# Altium schematic sheet STM32.SchDoc(STM32)
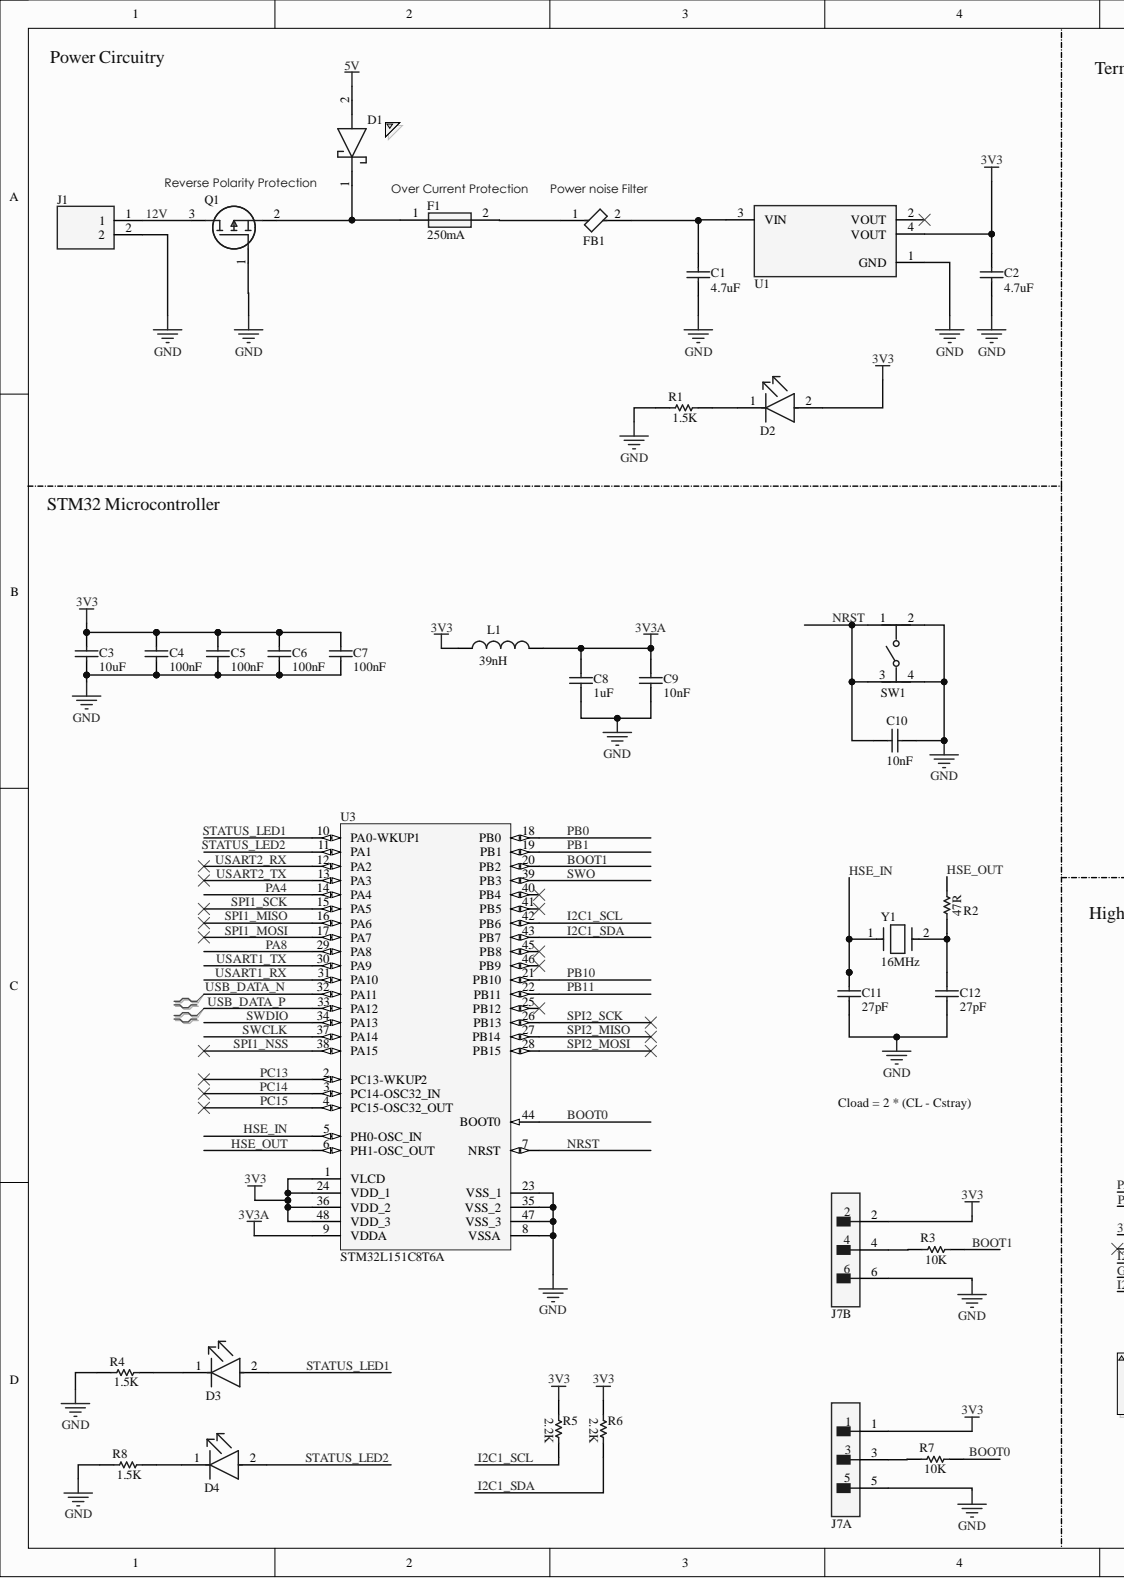
[diagram p0: STM32.SchDoc, left]
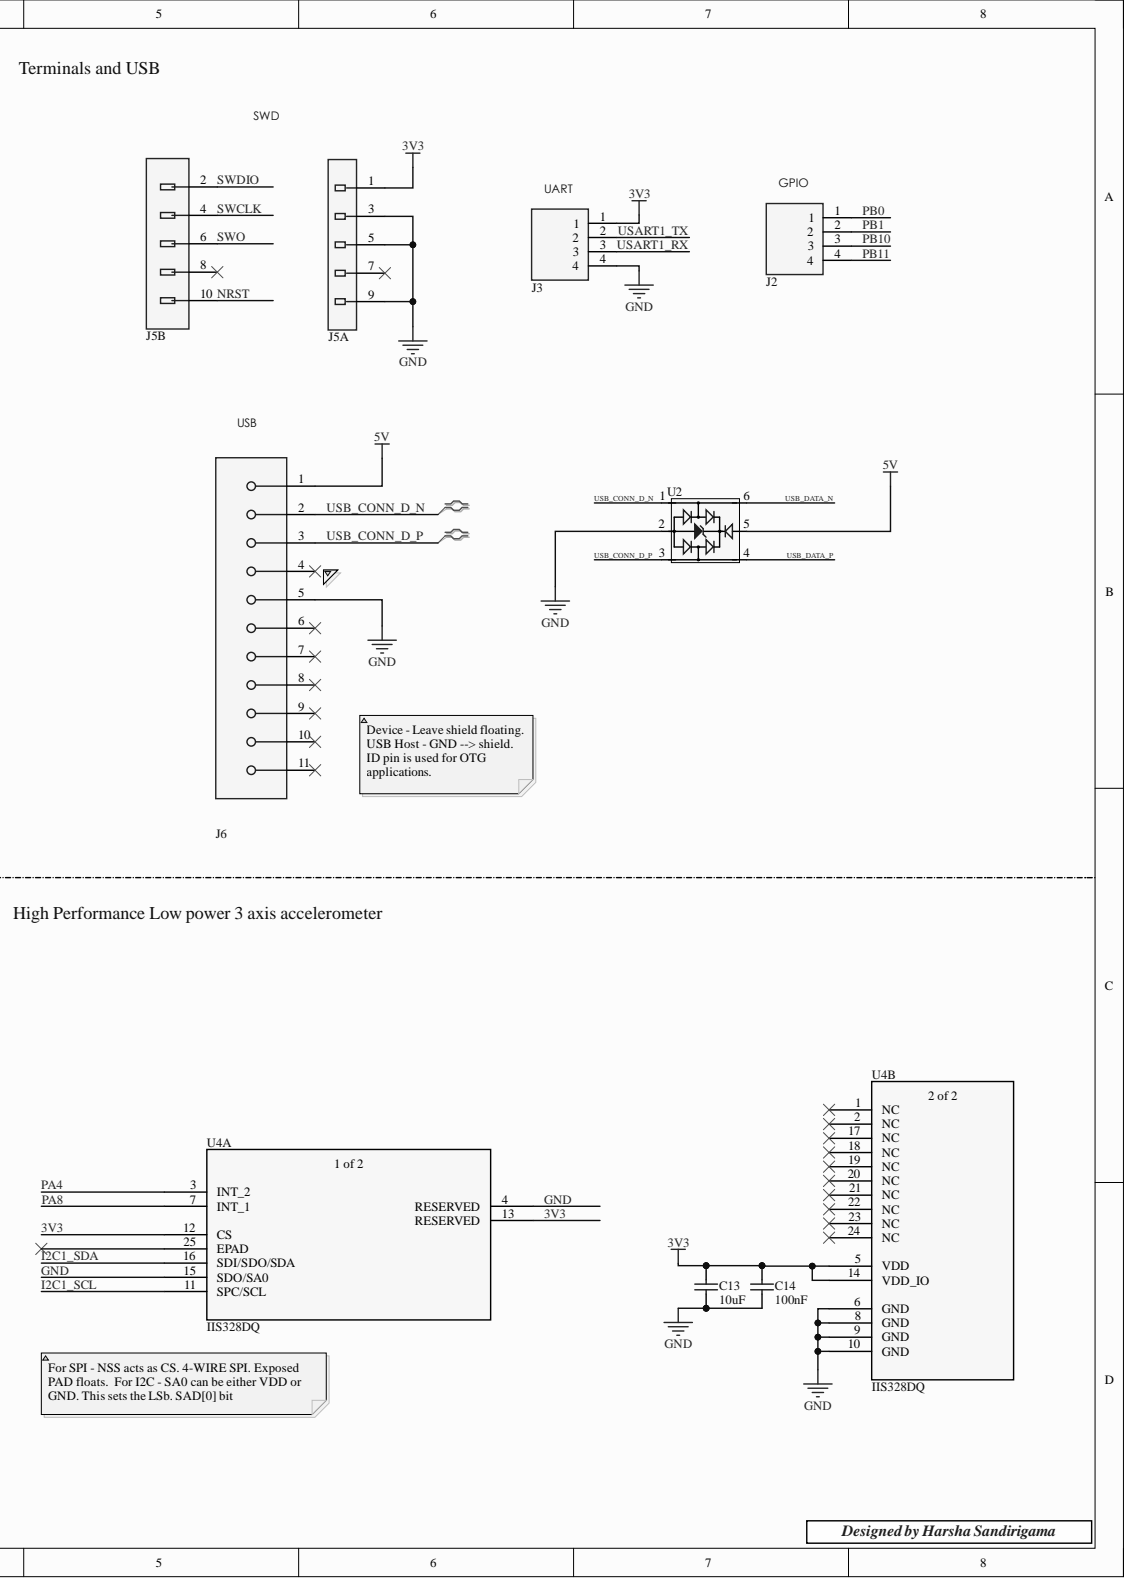
[diagram p1: STM32.SchDoc, right]

## Parts
C1  4.7uF  [0603C - HD]
C2  4.7uF  [0603C - HD]
C3  10uF  [0603C - HD]
C4  100nF  [0603C - HD]
C5  100nF  [0603C - HD]
C6  100nF  [0603C - HD]
C7  100nF  [0603C - HD]
C8  1uF  [0603C - HD]
C9  10nF  [0603C - HD]
C10  10nF  [0603C - HD]
C11  27pF  [0603C - HD]
C12  27pF  [0603C - HD]
C13  10uF  [0603C - HD]
C14  100nF  [0603C - HD]
D1  PMEG4005CEJX  [SODFL2512X80N]  (value not drawn)
D2  LTST-C191KFKT  [Lite-On_0_MFG]  (value not drawn)
D3  LTST-C191KFKT  [Lite-On_0_MFG]  (value not drawn)
D4  LTST-C191KFKT  [Lite-On_0_MFG]  (value not drawn)
F1  250mA  [FUSC3115X66N]
FB1  MPZ1608Y101BTA00  [TDK_MPZ1608-0_8_IPC_A]  (value not drawn)
J1  12V  [PJ037AH]
J2  TS-104-T-AA  [HDRV4W46P0X254_1X4_1016X254X808P]  (value not drawn)
J3  TS-104-T-AA  [HDRV4W46P0X254_1X4_1016X254X808P]  (value not drawn)
J5  GRPB052VWQS-RC  [Sullins_GRPB052VWQS-RC_MFG]  (value not drawn)  (parts A,B)
J6  Connector Type: USB  [Global_Connector_Technology_USB3075-30-A_0]  (hidden comment: USB3075-30-A)
J7  67996-406HLF  [Amphenol_ICC_-_FCI_0_MFG]  (value not drawn)  (parts A,B)
L1  39nH  [0603L - HD]
Q1  MOSFET P-CH  [SOT96P240X110-3N]  (value not drawn)
R1  RMCF0603FT1K50  [0603R - HD]  (value not drawn)
R2  47R  [0603R - HD]
R3  RNCF0603BTE10K0  [0603R - HD]  (value not drawn)
R4  RMCF0603FT1K50  [0603R - HD]  (value not drawn)
R5  RMCF0603FT2K20  [0603R - HD]  (value not drawn)
R6  RMCF0603FT2K20  [0603R - HD]  (value not drawn)
R7  RNCF0603BTE10K0  [0603R - HD]  (value not drawn)
R8  RMCF0603FT1K50  [0603R - HD]  (value not drawn)
SW1  PTS645SM43SMTR92LFS  [C&K_0_MFG - duplicate]  (value not drawn)
U1  ZLDO1117G33TA  [Diodes_SOT223_IPC_A]  (value not drawn)
U2  82400102  [SOT23_6L]  (value not drawn)
U3  STM32L151C8T6A  [QFP50P900X900X160-48N]
U4  IIS328DQ  [QFN-24_4X4MM_STM]  (parts A,B)
Y1  16MHz  [ECS_Inc._ECS-160-20-3X-TR_0]

## Nets
3V3A: C8.2 C9.2 L1.2 U3.9(VDDA)
5V: D1.2 J6.1 U2.5
3V3: C2.2 C3.2 C4.2 C5.2 C6.2 C7.2 C13.2 C14.2 D2.2 J3.1 J5.1 J7.1 J7.2 L1.1 R5.1 R6.1 U1.4(VOUT) U3.1(VLCD) U3.24(VDD_1) U3.36(VDD_2) U3.48(VDD_3) U4.5(VDD) U4.12(CS) U4.13(RESERVED) U4.14(VDD_IO)
12V: J1.1 Q1.3
BOOT0: R7.2 U3.44(BOOT0)
BOOT1: R3.2 U3.20(PB2)
GND: C1.1 C2.1 C3.1 C4.1 C5.1 C6.1 C7.1 C8.1 C9.1 C10.2 C11.1 C12.1 C13.1 C14.1 J1.2 J3.4 J5.3 J5.5 J5.9 J6.5 J7.5 J7.6 Q1.1 R1.1 R4.1 R8.1 SW1.2 SW1.4 U1.1(GND) U2.2 U3.8(VSSA) U3.23(VSS_1) U3.35(VSS_2) U3.47(VSS_3) U4.4(RESERVED) U4.6(GND) U4.8(GND) U4.9(GND) U4.10(GND) U4.15(SDO/SA0)
HSE_IN: C11.2 U3.5(PH0-OSC_IN) Y1.1
HSE_OUT: R2.2 U3.6(PH1-OSC_OUT)
I2C1_SCL: R5.2 U3.42(PB6) U4.11(SPC/SCL)
I2C1_SDA: R6.2 U3.43(PB7) U4.16(SDI/SDO/SDA)
NRST: C10.1 J5.10 SW1.1 SW1.3 U3.7(NRST)
PA4: U3.14(PA4) U4.3(INT_2)
PA8: U3.29(PA8) U4.7(INT_1)
PB0: J2.1 U3.18(PB0)
PB1: J2.2 U3.19(PB1)
PB10: J2.3 U3.21(PB10)
PB11: J2.4 U3.22(PB11)
PC13: U3.2(PC13-WKUP2)
PC14: U3.3(PC14-OSC32_IN)
PC15: U3.4(PC15-OSC32_OUT)
SPI1_MISO: U3.16(PA6)
SPI1_MOSI: U3.17(PA7)
SPI1_NSS: U3.38(PA15)
SPI1_SCK: U3.15(PA5)
SPI2_MISO: U3.27(PB14)
SPI2_MOSI: U3.28(PB15)
SPI2_SCK: U3.26(PB13)
STATUS_LED1: D3.2 U3.10(PA0-WKUP1)
STATUS_LED2: D4.2 U3.11(PA1)
SWCLK: J5.4 U3.37(PA14)
SWDIO: J5.2 U3.34(PA13)
SWO: J5.6 U3.39(PB3)
USART1_RX: J3.3 U3.31(PA10)
USART1_TX: J3.2 U3.30(PA9)
USART2_RX: U3.12(PA2)
USART2_TX: U3.13(PA3)
USB_CONN_D_N: J6.2 U2.1
USB_CONN_D_P: J6.3 U2.3
USB_DATA_N: U2.6 U3.32(PA11)
USB_DATA_P: U2.4 U3.33(PA12)
NetC1_2: C1.2 FB1.2 U1.3(VIN)
NetC12_2: C12.2 R2.1 Y1.2
NetD1_1: D1.1 F1.1 Q1.2
NetD2_1: D2.1 R1.2
NetD3_1: D3.1 R4.2
NetD4_1: D4.1 R8.2
NetF1_2: F1.2 FB1.1
NetJ7_3: J7.3 R7.1
NetJ7_4: J7.4 R3.1

Not connected: J5 7 8; J6 4 6 7 8 9 10 11; U1 2(VOUT); U3 25(PB12) 40(PB4) 41(PB5) 45(PB8) 46(PB9); U4 1(NC) 2(NC) 17(NC) 18(NC) 19(NC) 20(NC) 21(NC) 22(NC) 23(NC) 24(NC) 25(EPAD)

## Library data of the parts
C1 C2 = C1608X7S1A475K080AC: Cap Ceramic 4.7uF 10V X7S 10% SMD 0603 125°C Punched Paper T/R; Manufacturer: TDK; Core Architecture: ARM; Frequency: 48MHz; Interface: I2C,SPI,USB,IrDA,UART,USART,EBI/EMI; Memory Size: 64KB; Memory Type: FLASH; Number of I/Os: 53; Oscillator Type: Internal; Peripherals: Brown-out Detect/Reset,POR,PWM,WDT,DMA,LCD; Capacitance: 4.7uF; Case Code (Imperial): 0603; Case Code (Metric): 1608; Case/Package: LFBGA; Category: Ceramic Capacitors; Component Kind: Standard; ComponentLink1Description: https://datasheet.ciiva.com/14911/tdkmlcccaprange-14911942.pdf?src-supplier=Digi-Key; ComponentLink1URL: https://datasheet.ciiva.com/14911/tdkmlcccaprange-14911942.pdf?src-supplier=Digi-Key; ComponentLink2Description: https://datasheet.ciiva.com/14911/tdkmlcccaprange-14911943.pdf?src-supplier=Digi-Key; ComponentLink2URL: https://datasheet.ciiva.com/14911/tdkmlcccaprange-14911943.pdf?src-supplier=Digi-Key; ComponentLink3Description: https://datasheet.ciiva.com/14911/tdkmlcccaprange-14911944.pdf?src-supplier=Digi-Key; ComponentLink3URL: https://datasheet.ciiva.com/14911/tdkmlcccaprange-14911944.pdf?src-supplier=Digi-Key; Depth: 0.8mm; Dielectric: X7S; Dielectric Material: Ceramic; Lead Free: Lead Free; Length: 1.6mm; Manufacturer Part Number: C1608X7S1A475K080AC; Max Operating Temperature: 125°C; Min Operating Temperature: -40°C; Number of Pins: 64; Package Quantity: 1000; Packaging: Tape and Reel; Pricing 1: 1=0.30000, 10=0.20700, 50=0.12420, 100=0.10490, 500=0.07176, 1000=0.06348 (USD); REACH SVHC: No SVHC; RoHS: Yes; RoHS Compliant: Yes; Series: Leopard Gecko; Stock 1: 598789; Supplier 1: Digi-Key; Supplier Part Number 1: 445-14258-1-ND; Thickness: 800um; Tolerance: 10%; Voltage Rating: 10V; Voltage Rating (DC): 10V; Library Name: stm32devsch.SchLib
C3 C13 = 06036D106MAT2A: AVX - 06036D106MAT2A - SMD Multilayer Ceramic Capacitor, 10 µF, 6.3 V, 0603 [1608 Metric], ± 20%, X5R, AVX 0603 MLCC; Manufacturer: Kyocera AVX; Voltage: 6.3V; Capacitance: 10uF; Case Code (Imperial): 0603; Case Code (Metric): 1608; Case/Package: 0603; Category: Ceramic Capacitors; Component Kind: Standard; ComponentLink1Description: https://datasheet.ciiva.com/153/cx5r-153301.pdf?src-supplier=Digi-Key; ComponentLink1URL: https://datasheet.ciiva.com/153/cx5r-153301.pdf?src-supplier=Digi-Key; ComponentLink2Description: https://datasheet.ciiva.com/153/cx5r-153302.pdf?src-supplier=Digi-Key; ComponentLink2URL: https://datasheet.ciiva.com/153/cx5r-153302.pdf?src-supplier=Digi-Key; ComponentLink3Description: https://datasheet.ciiva.com/153/cx5r-153303.pdf?src-supplier=Digi-Key; ComponentLink3URL: https://datasheet.ciiva.com/153/cx5r-153303.pdf?src-supplier=Digi-Key; ComponentLink4Description: https://datasheet.ciiva.com/5422/cx5r-33896-5422935.pdf?src-supplier=Mouser; ComponentLink4URL: https://datasheet.ciiva.com/5422/cx5r-33896-5422935.pdf?src-supplier=Mouser; ComponentLink5Description: https://datasheet.ciiva.com/2987/cx5r-2987866.pdf?src-supplier=Avnet; ComponentLink5URL: https://datasheet.ciiva.com/2987/cx5r-2987866.pdf?src-supplier=Avnet; ComponentLink6Description: https://datasheet.ciiva.com/2987/cx5r-2987867.pdf?src-supplier=Avnet; ComponentLink6URL: https://datasheet.ciiva.com/2987/cx5r-2987867.pdf?src-supplier=Avnet; ComponentLink7Description: https://datasheet.ciiva.com/14591/70001028-14591754.pdf?src-supplier=Allied+Electronics; ComponentLink7URL: https://datasheet.ciiva.com/14591/70001028-14591754.pdf?src-supplier=Allied+Electronics; ComponentLink8Description: https://datasheet.ciiva.com/16201/cx5r-16201627.pdf?src-supplier=Future+Electronics; ComponentLink8URL: https://datasheet.ciiva.com/16201/cx5r-16201627.pdf?src-supplier=Future+Electronics; ComponentLink9Description: https://datasheet.ciiva.com/1458/1796776-1458117.pdf?src-supplier=Element14; ComponentLink9URL: https://datasheet.ciiva.com/1458/1796776-1458117.pdf?src-supplier=Element14; ComponentLink10Description: https://datasheet.ciiva.com/16538/cx5r-16538025.pdf?src-supplier=Avnet+Asia; ComponentLink10URL: https://datasheet.ciiva.com/16538/cx5r-16538025.pdf?src-supplier=Avnet+Asia; Construction: Flat; Contact Plating: Tin,Matte; Depth: 0.81mm; Dielectric: X5R; Dielectric Material: Ceramic; Height: 0.9mm; Lead Free: Lead Free; Length: 1.6mm; Manufacturer Part Number: 06036D106MAT2A; Max Operating Temperature: 85°C; Min Operating Temperature: -55°C; Mount: Surface Mount,PCB; Number of Pins: 2; Package Quantity: 1; Packaging: Tape and Reel; Pricing 1: 1=0.22000, 10=0.14900, 50=0.08940, 100=0.07550, 500=0.05164, 1000=0.04568 (USD); Pricing 2: 1=0.22000, 10=0.14900, 50=0.08940, 100=0.07550, 500=0.05164, 1000=0.04568 (USD); Radiation Hardening: No; REACH SVHC: No SVHC; RoHS: Yes; RoHS Compliant: Yes; Series: -; Stock 1: 923568; Stock 2: 923568; Supplier 1: Digi-Key; Supplier 2: Digi-Key; Supplier Part Number 1: 478-5318-1-ND; Supplier Part Number 2: 478-5318-6-ND; Termination: SMD/SMT; Thickness: 0.035inch; Tolerance: 20%; Voltage Rating: 6.3V; Voltage Rating (DC): 6.3V; Width: 0.032inch; Library Name: stm32devsch.SchLib
C4-C7 C14 = CL10B104KB8VPJC: 0.1 µF ±10% 50V Ceramic Capacitor X7R 0603 (1608 Metric); Manufacturer: Samsung; Category: Ceramic Capacitors; Component Kind: Standard; Manufacturer Part Number: CL10B104KB8VPJC; Pricing 1: 1=0.15000, 10=0.11000, 50=0.06240, 100=0.05180, 500=0.03630, 1000=0.03005 (USD); Pricing 2: 1=0.16000, 10=0.07500, 50=0.07500, 100=0.05500, 1000=0.03400, 10000=0.02400 (USD); RoHS: Yes; Stock 2: 5857; Supplier 1: Digi-Key; Supplier 2: Mouser; Supplier Part Number 1: 1276-CL10B104KB8VPJCCT-ND; Supplier Part Number 2: 187-CL10B104KB8VPJC; Library Name: stm32devsch.SchLib
C8 = 0603BB105K160YT: CAP CER 1UF 16V X7R 0603; Manufacturer: Knowles Novacap; Tolerance: 10%; Capacitance: 1uF; Case/Package: 0603; Category: Ceramic Capacitors; Component Kind: Standard; ComponentLink1Description: http://www.knowlescapacitors.com/getmedia/b8129730-c7fe-4622-a4e1-683ad9deee84/Novacap_catalog_9345-14.aspx?src-supplier=Digi-Key; ComponentLink1URL: http://www.knowlescapacitors.com/getmedia/b8129730-c7fe-4622-a4e1-683ad9deee84/Novacap_catalog_9345-14.aspx?src-supplier=Digi-Key; Manufacturer Part Number: 0603BB105K160YT; Max Operating Temperature: 125°C; Min Operating Temperature: -55°C; Mount: Surface Mount; Packaging: Cut Tape; RoHS: No; Supplier 1: Digi-Key; Supplier Part Number 1: 1763-1175-1-ND; Voltage Rating: 16V; Library Name: stm32devsch.SchLib
C9 C10 = CL10B103KB8WPJC: Capacitor Ceramic 0.01uF 10% 50V 2-Pin Surface Mount T/R; Manufacturer: Samsung; Category: Ceramic Capacitors; Component Kind: Standard; Manufacturer Part Number: CL10B103KB8WPJC; Pricing 1: 1=0.11000, 10=0.08100, 50=0.04620, 100=0.03830, 500=0.02684, 1000=0.02222 (USD); RoHS: Yes; RoHS Compliant: Yes; Stock 1: 74489; Supplier 1: Digi-Key; Supplier Part Number 1: 1276-CL10B103KB8WPJCCT-ND; Library Name: stm32devsch.SchLib
C11 C12 = 06035A270JAT2A: CAP MLCC, 27PF, 50V, C0G/NP0, 5%, 0603; Manufacturer: Kyocera AVX; Dielectric: C0G; Temperature Coefficient: 0ppm/°C; Capacitance: 27pF; Case Code (Imperial): 0603; Case Code (Metric): 1608; Case/Package: 0603; Category: Ceramic Capacitors; Component Kind: Standard; ComponentLink1Description: https://datasheet.ciiva.com/22738/759117-22738072.pdf?src-supplier=Element14; ComponentLink1URL: https://datasheet.ciiva.com/22738/759117-22738072.pdf?src-supplier=Element14; ComponentLink2Description: https://datasheet.ciiva.com/7855/759117-7855066.pdf?src-supplier=Element14; ComponentLink2URL: https://datasheet.ciiva.com/7855/759117-7855066.pdf?src-supplier=Element14; ComponentLink3Description: https://datasheet.ciiva.com/150/ccog-150312.pdf?src-supplier=Digi-Key; ComponentLink3URL: https://datasheet.ciiva.com/150/ccog-150312.pdf?src-supplier=Digi-Key; ComponentLink4Description: https://datasheet.ciiva.com/150/ccog-150311.pdf?src-supplier=Digi-Key; ComponentLink4URL: https://datasheet.ciiva.com/150/ccog-150311.pdf?src-supplier=Digi-Key; ComponentLink5Description: https://datasheet.ciiva.com/150/ccog-150313.pdf?src-supplier=Digi-Key; ComponentLink5URL: https://datasheet.ciiva.com/150/ccog-150313.pdf?src-supplier=Digi-Key; Contact Plating: Tin,Matte; Depth: 0.81mm; Height: 0.63mm; Lead Free: Lead Free; Length: 1.6mm; Manufacturer Part Number: 06035A270JAT2A; Max Operating Temperature: 125°C; Min Operating Temperature: -55°C; Mount: Surface Mount; Number of Pins: 2; Package Quantity: 1; Packaging: Tape and Reel; Pricing 1: 1=0.10000, 10=0.07000, 50=0.03800, 100=0.03100, 500=0.02214, 1000=0.01739 (USD); Radiation Hardening: No; REACH SVHC: No SVHC; RoHS: Yes; Stock 1: 36247; Supplier 1: Digi-Key; Supplier Part Number 1: 478-1168-1-ND; Termination: SMD/SMT; Thickness: 0.035inch; Tolerance: 5%; Voltage: 50V; Voltage Rating: 50V; Voltage Rating (DC): 50V; Width: 0.81mm; Library Name: stm32devsch.SchLib
D1 = PMEG4005CEJX: Rectifier Diode Schottky 40V 0.5A 1.8ns Automotive 2-Pin SOD-323F T/R; Manufacturer: Nexperia; Arrow Part Number: PMEG4005CEJX; Arrow Price/Stock: https://www.arrow.com/en/products/pmeg4005cejx/nexperia?region=nac; Category: Schottky Diodes; Component Kind: Standard; Datasheet Link: https://www.arrow.com/en/products/pmeg4005cejx/nexperia; Height: 0.8mm; Manufacturer Part Number: PMEG4005CEJX; Manufacturer_Name: Nexperia; Manufacturer_Part_Number: PMEG4005CEJX; Mouser Part Number: 771-PMEG4005CEJX; Mouser Price/Stock: https://www.mouser.co.uk/ProductDetail/Nexperia/PMEG4005CEJX?qs=1fQ7IOp4Wri3RvgD47E2KA%3D%3D; Pricing 1: 1=0.42000, 10=0.30900, 100=0.17490, 500=0.11584, 1000=0.08880 (USD); RoHS: Yes; RoHS Compliant: Yes; Stock 1: 29989; Supplier 1: Digi-Key; Supplier Part Number 1: 1727-8169-1-ND; Library Name: stm32devsch.SchLib
D2-D4 = LTST-C191KFKT: Orange 0603 130° Water Clear 90 mcd 2 V SMT Rectangular Flat Top LED; Manufacturer: Vishay Lite-On; Dominant Wavelength: 605nm; Forward Current: 30mA; Forward Voltage: 2V; Lens Color: Colorless; Lens Style: Diffused,Colorless,Rectangular; Luminous Intensity: 90mcd; Number of Elements: 1; Power Dissipation: 75mW; Reverse Voltage: 5V; Test Current: 20mA; Wavelength: 611nm; Case Code (Imperial): 0603; Case Code (Metric): 1608; Case/Package: 0603; Category: LEDs; Color: Orange; Component Kind: Standard; ComponentLink1Description: https://datasheet.ciiva.com/2109/ltst-c191kfkt-2109968.pdf?src-supplier=Digi-Key; ComponentLink1URL: https://datasheet.ciiva.com/2109/ltst-c191kfkt-2109968.pdf?src-supplier=Digi-Key; ComponentLink2Description: https://datasheet.ciiva.com/12400/100-ltst-c191kfkt-39904-12400811.pdf?src-supplier=Mouser; ComponentLink2URL: https://datasheet.ciiva.com/12400/100-ltst-c191kfkt-39904-12400811.pdf?src-supplier=Mouser; ComponentLink3Description: https://datasheet.ciiva.com/11775/0900766b80dc02b0-11775613.pdf?src-supplier=RSComponents; ComponentLink3URL: https://datasheet.ciiva.com/11775/0900766b80dc02b0-11775613.pdf?src-supplier=RSComponents; ComponentLink4Description: https://datasheet.ciiva.com/15201/getdatasheetpartid-631075-15201391.pdf?src-supplier=Verical; ComponentLink4URL: https://datasheet.ciiva.com/15201/getdatasheetpartid-631075-15201391.pdf?src-supplier=Verical; ComponentLink5Description: https://datasheet.ciiva.com/2109/s-110-ltst-c191kfkt-2109969.pdf?src-supplier=Digi-Key; ComponentLink5URL: https://datasheet.ciiva.com/2109/s-110-ltst-c191kfkt-2109969.pdf?src-supplier=Digi-Key; Depth: 0.8mm; Height: 0.55mm; Illumination Color: Orange; Lead Free: Lead Free; Length: 1.6mm; Lens Transparency: Clear; Manufacturer Part Number: LTST-C191KFKT; Max Operating Temperature: 85°C; Min Operating Temperature: -55°C; Mount: Surface Mount; Number of LEDs: 1; Number of Pins: 2; Package Quantity: 1; Packaging: Tape and Reel; Power Rating: 75mW; Pricing 1: 1=0.24000, 10=0.13200, 100=0.06110, 500=0.05640, 1000=0.04418, 2000=0.04324 (USD); Radiation Hardening: No; RoHS: Yes; RoHS Compliant: Yes; Stock 1: 298039; Supplier 1: Digi-Key; Supplier Part Number 1: 160-1445-1-ND; Viewing Angle: 130°; Width: 0.8mm; Library Name: stm32devsch.SchLib
F1 = C1Q250: Fuse Chip Very Fast Acting 0.25A 125V SMD Solder Pad 1206 Ceramic T/R CE/CSA/cULus; Manufacturer: Bel; Manufacturer_Name: Stewart Connector; Approvals: CSA,cULus,CE; DC Cold Resistance: 880mR; Fuse Type: Board Mount (Cartridge Style Excluded); Max Voltage Rating (DC): 63V; Power Dissipation: 100mW; Case Code (Imperial): 1206; Case Code (Metric): 3216; Case/Package: 1206; Category: Fuses; Component Kind: Standard; ComponentLink1Description: https://datasheet.ciiva.com/300/c1q-300584.pdf?src-supplier=Digi-Key; ComponentLink1URL: https://datasheet.ciiva.com/300/c1q-300584.pdf?src-supplier=Digi-Key; ComponentLink2Description: https://datasheet.ciiva.com/300/c1q-300585.pdf?src-supplier=Digi-Key; ComponentLink2URL: https://datasheet.ciiva.com/300/c1q-300585.pdf?src-supplier=Digi-Key; ComponentLink3Description: https://datasheet.ciiva.com/300/c1q-300586.pdf?src-supplier=Digi-Key; ComponentLink3URL: https://datasheet.ciiva.com/300/c1q-300586.pdf?src-supplier=Digi-Key; ComponentLink4Description: https://datasheet.ciiva.com/8264/c1q-8264010.pdf?src-supplier=Avnet; ComponentLink4URL: https://datasheet.ciiva.com/8264/c1q-8264010.pdf?src-supplier=Avnet; Contact Plating: Tin; Current Rating: 250mA; Datasheet Link: https://datasheet.datasheetarchive.com/originals/distributors/Datasheets-6/DSA-118457.pdf; Depth: 1.52mm; Height: 0.66mm; Housing Material: Ceramic; Lead Free: Lead Free; Length: 3.07mm; Manufacturer Part Number: C1Q250; Manufacturer_Part_Number: C1Q250; Max Operating Temperature: 125°C; Min Operating Temperature: -55°C; Mount: Surface Mount; Number of Pins: 2; Packaging: Tape and Reel; Pricing 1: 1=0.32000, 5=0.31200, 10=0.29900, 50=0.26680, 100=0.24840, 250=0.22080, 500=0.18400, 1000=0.17480 (USD); RoHS: Yes; RoHS Compliant: Yes; Series: C1Q; Stock 1: 66554; Supplier 1: Digi-Key; Supplier Part Number 1: 507-1073-1-ND; Voltage Rating: 125V; Voltage Rating (AC): 125V; Voltage Rating (DC): 63V; Width: 0.062inch; Library Name: stm32devsch.SchLib
FB1 = MPZ1608Y101BTA00: Ind Chip Bead Multi-Layer 100Ohm 25% 100MHz Ferrite 2A 0603 Punched Paper T/R; Manufacturer: TDK; DC Resistance (DCR): 40mR; Impedance: 100R; Case Code (Imperial): 0603; Case Code (Metric): 1608; Case/Package: 0603; Category: Ferrite Beads and Chips; Component Kind: Standard; ComponentLink1Description: https://datasheet.ciiva.com/46634/beads-commercial-power-mpz-en-46634621.pdf?src-supplier=Digi-Key; ComponentLink1URL: https://datasheet.ciiva.com/46634/beads-commercial-power-mpz-en-46634621.pdf?src-supplier=Digi-Key; ComponentLink2Description: https://datasheet.ciiva.com/46634/beads-commercial-power-mpz-en-46634677.pdf?src-supplier=Digi-Key; ComponentLink2URL: https://datasheet.ciiva.com/46634/beads-commercial-power-mpz-en-46634677.pdf?src-supplier=Digi-Key; ComponentLink3Description: https://datasheet.ciiva.com/46634/beads-commercial-power-mpz-en-46634684.pdf?src-supplier=Digi-Key; ComponentLink3URL: https://datasheet.ciiva.com/46634/beads-commercial-power-mpz-en-46634684.pdf?src-supplier=Digi-Key; ComponentLink4Description: https://datasheet.ciiva.com/48682/beads-commercial-power-mpz-en-48682501.pdf?src-supplier=Avnet; ComponentLink4URL: https://datasheet.ciiva.com/48682/beads-commercial-power-mpz-en-48682501.pdf?src-supplier=Avnet; Core Material: Ferrite; Current Rating: 2A; Height: 0.037inch; Length: 0.063inch; Manufacturer Part Number: MPZ1608Y101BTA00; Max DC Current: 2A; Mount: Surface Mount; Package Quantity: 4000; Packaging: Tape and Reel; Pricing 1: 1=0.10000, 10=0.07900, 25=0.07160, 50=0.06440, 100=0.04720, 250=0.03432, 500=0.03146, 1000=0.02717 (USD); REACH SVHC: No SVHC; RoHS: Yes; RoHS Compliant: Yes; Series: MPZ; Stock 1: 221776; Supplier 1: Digi-Key; Supplier Part Number 1: 445-2984-1-ND; Tolerance: 25%; Width: 0.031inch; Library Name: stm32devsch.SchLib
J1 = PJ-037AH: CONN PWR JACK 2X5.5MM SOLDER; Manufacturer: CUI; Manufacturer_Name: CUI Inc.; Current Rating: 5A; Gender: Male; Insulation Resistance: 100MR; Number of Conductors: 2; Shielding: Unshielded; Voltage Rating: 24V; Arrow Part Number: PJ-037AH; Arrow Price/Stock: https://www.arrow.com/en/products/pj-037ah/cui-devices?region=nac; Category: Connectors; Color: Black; Component Kind: Standard; ComponentLink1Description: http://www.mouser.com/ds/2/670/pj-037ah-516064.pdf?src-supplier=Mouser; ComponentLink1URL: http://www.mouser.com/ds/2/670/pj-037ah-516064.pdf?src-supplier=Mouser; ComponentLink2Description: https://www.cui.com/product/resource/digikeypdf/pj-037ah.pdf?src-supplier=Digi-Key; ComponentLink2URL: https://www.cui.com/product/resource/digikeypdf/pj-037ah.pdf?src-supplier=Digi-Key; Connector Type: Jack; Contact Gender: Male; Contact Material: Copper; Contact Plating: Nickel; Datasheet Link: https://www.arrow.com/en/products/pj-037ah/cui-devices; Flammability Rating: UL94 V-0; Height: 11.2mm; Housing Color: Black; Housing Material: Thermoplastic; Lead Free: Lead Free; Manufacturer Part Number: PJ-037AH; Manufacturer_Part_Number: PJ-037AH; Max Operating Temperature: 85°C; Min Operating Temperature: -25°C; Mount: Through Hole; Mouser Part Number: 490-PJ-037AH; Mouser Price/Stock: https://www.mouser.co.uk/ProductDetail/CUI-Devices/PJ-037AH?qs=WyjlAZoYn50M7m1AkzfPaQ%3D%3D; Orientation: Horizontal; Package Quantity: 500; Pricing 1: 1=0.71000, 10=0.62200, 25=0.56880, 50=0.53520, 100=0.51290, 250=0.46832, 500=0.44600, 1000=0.36795, 2500=0.33450 (USD); RoHS: Yes; RoHS Compliant: Yes; Series: -; Stock 1: 64914; Supplier 1: Digi-Key; Supplier Part Number 1: CP-037AH-ND; Termination: Solder; Library Name: stm32devsch.SchLib
J2 J3 = TS-104-T-AA: Connector; Manufacturer_Name: SAMTEC; Arrow Part Number: TS-104-T-AA; Arrow Price/Stock: https://www.arrow.com/en/products/ts-104-t-aa/samtec?region=nac; Component Kind: Standard; Datasheet Link: https://componentsearchengine.com/Datasheets/2/TS-104-T-AA.pdf; Height: 8.08mm; Manufacturer_Part_Number: TS-104-T-AA; Mouser Part Number: 200-TS104TAA; Mouser Price/Stock: https://www.mouser.co.uk/ProductDetail/Samtec/TS-104-T-AA?qs=0lQeLiL1qyZ41w70Oe2T%2Fg%3D%3D; Library Name: stm32devsch.SchLib
J5 = GRPB052VWQS-RC: Male, 10 C, SMT, .050" CC; 3.00mm Head/5.10mm Tail, Sf Mt; No GP, Nylon 9T, Brass, 105 C; Manufacturer: Sullins; Connector Type: Male Pin; Number of Positions: 10; Pitch: 0.05inch; Category: Headers and Wire Housings; Component Kind: Standard; ComponentLink1Description: https://datasheet.ciiva.com/726/grpb-20-2vwqs-rc2010958-c-726624.pdf?src-supplier=Digi-Key; ComponentLink1URL: https://datasheet.ciiva.com/726/grpb-20-2vwqs-rc2010958-c-726624.pdf?src-supplier=Digi-Key; Contact Mating Length: 0.118inch; Contact Plating: Gold; Contact Type: Male Pin; Lead Free: Lead Free; Manufacturer Part Number: GRPB052VWQS-RC; Mount: Surface Mount; Number of Rows: 2; Package Quantity: 100; Packaging: Bulk; Pricing 1: 1=1.05000, 10=0.88400, 96=0.79396, 480=0.64963, 960=0.57744, 4992=0.52130 (USD); RoHS: Yes; RoHS Compliant: Yes; Row Spacing: 0.05inch; Stock 1: 18930; Supplier 1: Digi-Key; Supplier Part Number 1: S9012E-05-ND; Termination: Solder; Library Name: stm32devsch.SchLib
J6 = USB3075-30-A: Conn Micro USB Type B RCP 2Power/3Signal POS 0.65mm Solder RA SMD 5 Terminal 1 Port T/R; Manufacturer: Global Connector Technology; Gender: Receptacle; Number of Contacts: 5; USB Standard: USB 2.0; Category: USB Connectors; Component Kind: Standard; ComponentLink1Description: https://datasheet.ciiva.com/5803/usb3075-5803809.pdf?src-supplier=Farnell; ComponentLink1URL: https://datasheet.ciiva.com/5803/usb3075-5803809.pdf?src-supplier=Farnell; ComponentLink2Description: https://datasheet.ciiva.com/46420/usb3075-46420605.pdf?src-supplier=Element14; ComponentLink2URL: https://datasheet.ciiva.com/46420/usb3075-46420605.pdf?src-supplier=Element14; ComponentLink3Description: https://datasheet.ciiva.com/7633/1912469-7633847.pdf?src-supplier=Element14; ComponentLink3URL: https://datasheet.ciiva.com/7633/1912469-7633847.pdf?src-supplier=Element14; ComponentLink4Description: https://datasheet.ciiva.com/16502/usb3075-16502716.pdf?src-supplier=Future+Electronics; ComponentLink4URL: https://datasheet.ciiva.com/16502/usb3075-16502716.pdf?src-supplier=Future+Electronics; Contact Material: Copper Alloy; Contact Plating: Gold; Height: 0.525inch; Lead Free: Lead Free; Length: 0.525inch; Manufacturer Part Number: USB3075-30-A; Mount: Surface Mount; Orientation: Right Angle; Pricing 1: 1=0.87500, 250=0.67300, 500=0.60600, 1000=0.55200, 1500=0.55200, 5000=0.50500 (USD); Pricing 2: 1500=0.33300, 7500=0.32600, 15000=0.32000, 30000=0.31300 (GBP); REACH SVHC: No SVHC; RoHS: Yes; RoHS Compliant: Yes; Series: USB3075; Stock 1: 25500; Stock 2: 25500; Supplier 1: Newark; Supplier 2: Farnell; Supplier Part Number 1: 69AC6678; Supplier Part Number 2: 2443116; Termination: SMD/SMT; Weight: 0.001lb; Width: 0.525inch; Library Name: stm32devsch.SchLib
J7 = 67996-406HLF: CONN HEADER VERT 6POS 2.54MM; Manufacturer: Amphenol ICC / FCI; Connector Type: Male Pin; Contact Post Length: 2.41mm; Current Rating: 3A; Gender: Male; Insulation Resistance: 5GR; Max Voltage Rating (AC): 1.5kV; Number of Contacts: 6; Row Spacing: 0.1inch; Category: Headers and Wire Housings; Color: Black; Component Kind: Standard; ComponentLink1Description: https://datasheet.ciiva.com/46393/1876884-46393070.pdf?src-supplier=Element14; ComponentLink1URL: https://datasheet.ciiva.com/46393/1876884-46393070.pdf?src-supplier=Element14; ComponentLink2Description: https://datasheet.ciiva.com/728/67996-728895.pdf?src-supplier=Digi-Key; ComponentLink2URL: https://datasheet.ciiva.com/728/67996-728895.pdf?src-supplier=Digi-Key; ComponentLink3Description: https://datasheet.ciiva.com/3205/67996-3205613.pdf?src-supplier=Avnet; ComponentLink3URL: https://datasheet.ciiva.com/3205/67996-3205613.pdf?src-supplier=Avnet; ComponentLink4Description: https://datasheet.ciiva.com/16726/67996-16726281.pdf?src-supplier=Avnet+Europe; ComponentLink4URL: https://datasheet.ciiva.com/16726/67996-16726281.pdf?src-supplier=Avnet+Europe; ComponentLink5Description: https://datasheet.ciiva.com/14639/70236872-14639895.pdf?src-supplier=Allied+Electronics; ComponentLink5URL: https://datasheet.ciiva.com/14639/70236872-14639895.pdf?src-supplier=Allied+Electronics; Contact Gender: Male; Contact Material: Bronze; Contact Mating Length: 0.23inch; Contact Plating: Tin; Contact Type: Male Pin; Depth: 4.83mm; Housing Color: Black; Housing Material: Thermoplastic; Length: 7.62mm; Manufacturer Part Number: 67996-406HLF; Max Operating Temperature: 125°C; Min Operating Temperature: -65°C; Mount: Through Hole; Number of Positions: 6; Number of Rows: 2; Orientation: Straight; Package Quantity: 100; Packaging: Bulk; Pitch: 2.54mm; Pricing 1: 1=0.29000, 10=0.27100, 100=0.18940, 500=0.16234, 1000=0.13528, 5000=0.11595, 10000=0.10822 (USD); Radiation Hardening: No; REACH SVHC: No SVHC; RoHS: Yes; RoHS Compliant: Yes; Series: BergStik; Stock 1: 5419; Supplier 1: Digi-Key; Supplier Part Number 1: 609-3218-ND; Termination: Solder; Voltage Rating: 1.5kV; Library Name: stm32devsch.SchLib
L1 = HK160839NJ-T: Ind High Frequency Chip Multi-Layer 39nH 5% 100MHz 12Q-Factor Ceramic 300mA 0603 T/R; Manufacturer: Taiyo Yuden; Core Material: Ferrite,Ceramic; DC Resistance (DCR): 600mR; Q Factor: 12; Self Resonant Frequency: 1.1GHz; Shielding: Unshielded; Body Material: Ceramic; Case Code (Imperial): 0603; Case Code (Metric): 1608; Case/Package: 0603; Category: Fixed Inductors; Component Kind: Standard; ComponentLink1Description: https://datasheet.ciiva.com/8499/hk160839nj-t-ss-8499010.pdf?src-supplier=Avnet; ComponentLink1URL: https://datasheet.ciiva.com/8499/hk160839nj-t-ss-8499010.pdf?src-supplier=Avnet; ComponentLink2Description: https://datasheet.ciiva.com/30193/1171hk160839nj-t-30193223.pdf?src-supplier=Onlinecomponents.com; ComponentLink2URL: https://datasheet.ciiva.com/30193/1171hk160839nj-t-30193223.pdf?src-supplier=Onlinecomponents.com; ComponentLink3Description: https://datasheet.ciiva.com/7153/hk160839nj-t-ss-7153953.pdf?src-supplier=Newark; ComponentLink3URL: https://datasheet.ciiva.com/7153/hk160839nj-t-ss-7153953.pdf?src-supplier=Newark; Current Rating: 300mA; Halogen Free: Halogen Free; Height: 0.8mm; Height - Seated (Max): 0.037inch; Inductance: 39nH; Inductance Tolerance: 5%; Lead Free: Exempt; Length: 0.063inch; Manufacturer Part Number: HK160839NJ-T; Max DC Current: 300mA; Max Operating Temperature: 85°C; Min Operating Temperature: -40°C; Mount: Surface Mount; Number of Pins: 2; Package Quantity: 4000; Packaging: Tape and Reel; Pricing 1: 1=0.11000, 10=0.09600, 25=0.08560, 50=0.07280, 100=0.06430, 250=0.05892, 500=0.05356, 1000=0.04498 (USD); REACH SVHC: Unknown; RoHS: Yes; RoHS Compliant: Yes; Series: HK; Stock 1: 39663; Supplier 1: Digi-Key; Supplier Part Number 1: 587-1552-1-ND; Termination: SMD/SMT; Test Frequency: 100MHz; Tolerance: 5%; Width: 0.031inch; Library Name: stm32devsch.SchLib
Q1 = DMP3130LQ-7: Trans MOSFET P-CH 30V 3.5A Automotive 3-Pin SOT-23 T/R; Manufacturer: Diodes; Manufacturer_Name: Diodes Inc.; Drain to Source Resistance: 150mR; Input Capacitance: 864pF; Max Power Dissipation: 700mW; Rds On Max: 77mR; Arrow Part Number: DMP3130LQ-7; Arrow Price/Stock: https://www.arrow.com/en/products/dmp3130lq-7/diodes-incorporated; Case/Package: TO-236-3; Category: MOSFETs; Component Kind: Standard; ComponentLink1Description: https://datasheet.ciiva.com/16438/ds31524-16438717.pdf?src-supplier=Future+Electronics; ComponentLink1URL: https://datasheet.ciiva.com/16438/ds31524-16438717.pdf?src-supplier=Future+Electronics; Continuous Drain Current (ID): 3.5A; Datasheet Link: https://componentsearchengine.com/Datasheets/1/DMP3130LQ-7.pdf; Drain to Source Voltage (Vdss): 30V; Height: 1.1mm; Lead Free: Lead Free; Manufacturer Part Number: DMP3130LQ-7; Manufacturer_Part_Number: DMP3130LQ-7; Max Operating Temperature: 150°C; Min Operating Temperature: -55°C; Mount: Surface Mount; Mouser Part Number: 621-DMP3130LQ-7; Mouser Price/Stock: https://www.mouser.co.uk/ProductDetail/Diodes-Incorporated/DMP3130LQ-7?qs=IXOKsUZacBE0XFAwZisciA%3D%3D; Packaging: Cut Tape; Pricing 1: 1=0.50000, 10=0.40700, 100=0.27740, 500=0.20804, 1000=0.15602 (USD); REACH SVHC: No SVHC; RoHS: Yes; RoHS Compliant: Yes; Stock 1: 432; Supplier 1: Digi-Key; Supplier Part Number 1: DMP3130LQ-7DICT-ND; Library Name: stm32devsch.SchLib
R1 R4 R8 = RMCF0603FT1K50: Res Thick Film 0603 1.5K Ohm 1% 0.1W(1/10W) ±100ppm/C Pad SMD Automotive T/R; Manufacturer: Stackpole Electronics; Max Power Dissipation: 100mW; Resistance: 1.5kR; Temperature Coefficient: 100ppm/°C; Case Code (Imperial): 0603; Case Code (Metric): 1608; Case/Package: 0603; Category: Chip SMD Resistors; Component Kind: Standard; ComponentLink1Description: http://components.arrow.com/part/dataview/44988068S6965504N1174?src-supplier=Arrow+Electronics; ComponentLink1URL: http://components.arrow.com/part/dataview/44988068S6965504N1174?src-supplier=Arrow+Electronics; ComponentLink2Description: https://www.seielect.com/catalog/SEI-Packaging.pdf?src-supplier=Digi-Key; ComponentLink2URL: https://www.seielect.com/catalog/SEI-Packaging.pdf?src-supplier=Digi-Key; ComponentLink3Description: https://www.seielect.com/catalog/SEI-RMCF_RMCP.pdf?src-supplier=Avnet; ComponentLink3URL: https://www.seielect.com/catalog/SEI-RMCF_RMCP.pdf?src-supplier=Avnet; ComponentLink4Description: https://www.verical.com/server-webapp/html/getDatasheet?partID=373264&src-supplier=Verical; ComponentLink4URL: https://www.verical.com/server-webapp/html/getDatasheet?partID=373264&src-supplier=Verical; Construction: Rectangular; Contact Plating: Tin,Matte; Depth: 0.8mm; Features: Automotive AEC-Q200; Height: 0.022inch; Length: 1.55mm; Manufacturer Part Number: RMCF0603FT1K50; Max Operating Temperature: 155°C; Military Standard: Not; Min Operating Temperature: -55°C; Mount: Surface Mount; Number of Terminations: 2; Packaging: Tape and Reel; Pricing 1: 1=0.10000, 10=0.01700, 100=0.00680, 1000=0.00305, 2500=0.00264 (USD); Radiation Hardening: No; Resistor Type: Thick Film; RoHS: Yes; RoHS Compliant: Yes; Series: RMCF; Stock 1: 1162707; Supplier 1: Digi-Key; Supplier Part Number 1: RMCF0603FT1K50CT-ND; Tolerance: 1%; Width: 0.031inch; Library Name: stm32devsch.SchLib
R2 = RCS060347R0FKEA: RES SMD 47 OHM 1% 1/4W 0603; Manufacturer: Vishay Dale; Max Power Dissipation: 250mW; Temperature Coefficient: 100ppm/°C; Case/Package: 0603; Category: Chip SMD Resistors; Component Kind: Standard; ComponentLink1Description: http://www.vishay.com/docs/20065/rcse3.pdf?src-supplier=Digi-Key; ComponentLink1URL: http://www.vishay.com/docs/20065/rcse3.pdf?src-supplier=Digi-Key; Features: Automotive AEC-Q200; Height: 0.02inch; Length: 0.061inch; Manufacturer Part Number: RCS060347R0FKEA; Max Operating Temperature: 155°C; Min Operating Temperature: -55°C; Number of Terminations: 2; Package Quantity: 5000; Packaging: Tape and Reel; Pricing 1: 1=0.15000, 10=0.13300, 100=0.05120, 1000=0.02252, 2500=0.01945 (USD); Resistance: 47R; Resistor Type: Thick Film; RoHS: Yes; Stock 1: 18040; Supplier 1: Digi-Key; Supplier Part Number 1: 541-10470-1-ND; Tolerance: 1%; Width: 0.033inch; Library Name: stm32devsch.SchLib
R3 R7 = RNCF0603BTE10K0: RES 10K OHM 0.1% 1/10W 0603; Component Kind: Standard; Supplier 1: Digi-Key; Supplier Part Number 1: RNCF0603BTE10K0CT-ND; Library Name: stm32devsch.SchLib
R5 R6 = RMCF0603FT2K20: Res Thick Film 0603 2.2K Ohm 1% 0.1W(1/10W) ±100ppm/C Pad SMD Automotive T/R; Manufacturer: Stackpole Electronics; Max Power Dissipation: 100mW; Resistance: 2.2kR; Temperature Coefficient: 100ppm/°C; Case Code (Imperial): 0603; Case Code (Metric): 1608; Case/Package: 0603; Category: Chip SMD Resistors; Component Kind: Standard; ComponentLink1Description: http://components.arrow.com/part/dataview/46931077S7088098N1174?src-supplier=Arrow+Electronics; ComponentLink1URL: http://components.arrow.com/part/dataview/46931077S7088098N1174?src-supplier=Arrow+Electronics; ComponentLink2Description: https://www.seielect.com/catalog/SEI-Packaging.pdf?src-supplier=Digi-Key; ComponentLink2URL: https://www.seielect.com/catalog/SEI-Packaging.pdf?src-supplier=Digi-Key; ComponentLink3Description: https://www.seielect.com/catalog/SEI-RMCF_RMCP.pdf?src-supplier=Avnet+Asia; ComponentLink3URL: https://www.seielect.com/catalog/SEI-RMCF_RMCP.pdf?src-supplier=Avnet+Asia; ComponentLink4Description: https://www.seielect.com/catalog/SEI-RMCF_RMCP.pdf?src-supplier=Avnet; ComponentLink4URL: https://www.seielect.com/catalog/SEI-RMCF_RMCP.pdf?src-supplier=Avnet; ComponentLink5Description: http://www.seielect.com/Catalog/SEI-rmc.pdf?src-supplier=Future+Electronics; ComponentLink5URL: http://www.seielect.com/Catalog/SEI-rmc.pdf?src-supplier=Future+Electronics; Contact Plating: Tin,Matte; Features: Automotive AEC-Q200; Height: 0.022inch; Lead Free: Lead Free; Length: 0.061inch; Manufacturer Part Number: RMCF0603FT2K20; Max Operating Temperature: 155°C; Min Operating Temperature: -55°C; Mount: Surface Mount; Number of Terminations: 2; Packaging: Tape and Reel; Power Rating: 100mW; Pricing 1: 1=0.10000, 10=0.01700, 100=0.00680, 1000=0.00305, 2500=0.00264 (USD); Radiation Hardening: No; Resistor Type: Thick Film; RoHS: Yes; RoHS Compliant: Yes; Series: RMCF; Stock 1: 1700907; Supplier 1: Digi-Key; Supplier Part Number 1: RMCF0603FT2K20CT-ND; Tolerance: 1%; Width: 0.031inch; Library Name: stm32devsch.SchLib
SW1 = PTS645SM43SMTR92LFS: SWITCH TACTILE SPST-NO 0.05A 12V; Manufacturer: ITT C&K; Actuator Length: 0.85mm; Actuator Material: Nylon,Polyamide,PA66; Actuator Type: Standard; Contact Resistance: 100mR; Current Rating: 50mA; Illumination Type: Non-Illuminated,Illuminated; Mechanical Life: 100000Cycles; Operating Force: 160gf; Switch Function: Off-Mom; Throw Configuration: SPST; Case/Package: SMD/SMT; Category: Tactile Switches; Color: Black; Component Kind: Standard; ComponentLink1Description: https://datasheet.ciiva.com/29931/getdatasheetpartid-764615-29931183.pdf?src-supplier=Verical; ComponentLink1URL: https://datasheet.ciiva.com/29931/getdatasheetpartid-764615-29931183.pdf?src-supplier=Verical; ComponentLink2Description: https://datasheet.ciiva.com/29903/getdatasheetpartid-786341-29903846.pdf?src-supplier=Verical; ComponentLink2URL: https://datasheet.ciiva.com/29903/getdatasheetpartid-786341-29903846.pdf?src-supplier=Verical; ComponentLink3Description: https://datasheet.ciiva.com/14920/pts645-14920733.pdf?src-supplier=Digi-Key; ComponentLink3URL: https://datasheet.ciiva.com/14920/pts645-14920733.pdf?src-supplier=Digi-Key; ComponentLink4Description: https://datasheet.ciiva.com/14920/pts645-14920734.pdf?src-supplier=Digi-Key; ComponentLink4URL: https://datasheet.ciiva.com/14920/pts645-14920734.pdf?src-supplier=Digi-Key; ComponentLink5Description: https://datasheet.ciiva.com/14920/pts645-14920735.pdf?src-supplier=Digi-Key; ComponentLink5URL: https://datasheet.ciiva.com/14920/pts645-14920735.pdf?src-supplier=Digi-Key; Contact Material: Silver; Contact Plating: Silver; Depth: 9mm; Lead Free: Lead Free; Length: 6mm; Manufacturer Part Number: PTS645SM43SMTR92LFS; Max Current Rating: 50mA; Max Operating Temperature: 60°C; Max Voltage Rating (DC): 12V; Min Operating Temperature: -20°C; Mount: Surface Mount; Orientation: Straight; Package Quantity: 1; Packaging: Tape and Reel; Pricing 1: 1=0.25000, 10=0.24200, 25=0.22960, 100=0.20780, 250=0.18944, 500=0.17610 (USD); REACH SVHC: No SVHC; RoHS: Yes; RoHS Compliant: Yes; Series: PTS645; Size: 6mm; Stock 1: 1121749; Supplier 1: Digi-Key; Supplier Part Number 1: CKN9112CT-ND; Termination: Gull Wing; Voltage Rating (DC): 12V; Library Name: stm32devsch.SchLib
U1 = ZLDO1117G33TA: LDO Regulator Pos 3.3V 1A 4-Pin(3+Tab) SOT-223 T/R / IC REG LDO 3.3V 1A SOT223; Manufacturer: Diodes Zetex; Accuracy: 2%; Dropout Voltage: 1.11V; Max Input Voltage: 18V; Max Power Dissipation: 15W; Min Current Limit: 1.1A; Min Input Voltage: 2.7V; Number of Outputs: 1; Output Type: Fixed; Polarity: Positive; Quiescent Current: 10mA; Case/Package: SOT-223; Category: Voltage Regulators - Linear; Component Kind: Standard; ComponentLink1Description: https://datasheet.ciiva.com/8078/608833-8078233.pdf?src-supplier=Element14; ComponentLink1URL: https://datasheet.ciiva.com/8078/608833-8078233.pdf?src-supplier=Element14; Height: 1.7mm; Lead Free: Lead Free; Length: 6.7mm; Manufacturer Part Number: ZLDO1117G33TA; Max Operating Temperature: 125°C; Max Output Current: 1A; Min Operating Temperature: -40°C; Min Output Voltage: 3.3V; Mount: Surface Mount; Nominal Output Voltage: 3.3V; Number of Pins: 3; Number of Regulators: 1; Output Current: 1A; Output Voltage: 3.3V; Output Voltage Accuracy: 2%; Package Quantity: 1000; Packaging: Tape and Reel; Power Dissipation: 15W; Pricing 1: 1=0.54000, 10=0.45900, 25=0.42840, 100=0.34280, 250=0.31832, 500=0.26934 (USD); Radiation Hardening: No; REACH SVHC: No SVHC; Reference Voltage: 3.3V; RoHS: Yes; RoHS Compliant: Yes; Series: ZLDO1117; Stock 1: 246729; Supplier 1: Digi-Key; Supplier Part Number 1: ZLDO1117G33DICT-ND; Tolerance: 2%; Weight: 0.000282oz; Width: 3.7mm; Library Name: stm32devsch.SchLib
U2 = CMP-1486-00007-1: TVS Diode Array WE-TVS 2-Ch+VDD 5V SOT23; Manufacturer: Wuerth Elektronik; Breakdown Voltage: 6V; Capacitance: 2pF; Clamping Voltage: 7.7V; Direction: Unidirectional; Element Configuration: Dual; ESD Protection: Yes; Max Reverse Leakage Current: 5A; Max Surge Current: 6A; Number of Bidirectional Channels: 2; Polarity: Bidirectional; Test Current: 1mA; Case/Package: SOT-23; Category: Diodes; Component Kind: Standard; ComponentLink1Description: Manufacturer URL; ComponentLink1URL: http://www.we-online.com; ComponentLink2Description: Datasheet; ComponentLink2URL: http://katalog.we-online.de/kataloge/eisos/media/pdf/82400102.pdf; ComponentLink3Description: https://datasheet.ciiva.com/15063/82400102-15063552.pdf?src-supplier=Digi-Key; ComponentLink3URL: https://datasheet.ciiva.com/15063/82400102-15063552.pdf?src-supplier=Digi-Key; ComponentLink4Description: https://datasheet.ciiva.com/22694/82400102-22694066.pdf?src-supplier=Element14; ComponentLink4URL: https://datasheet.ciiva.com/22694/82400102-22694066.pdf?src-supplier=Element14; ComponentLink5Description: https://datasheet.ciiva.com/1276/82400102-1276088.pdf?src-supplier=Element14; ComponentLink5URL: https://datasheet.ciiva.com/1276/82400102-1276088.pdf?src-supplier=Element14; ComponentLink6Description: https://datasheet.ciiva.com/26308/82400102-eng-tds-26308059.pdf?src-supplier=Distrelec; ComponentLink6URL: https://datasheet.ciiva.com/26308/82400102-eng-tds-26308059.pdf?src-supplier=Distrelec; ComponentLink7Description: https://datasheet.ciiva.com/48698/001086791-da-01-ml-tvs-diode-array-we-tvs-82400102-de-en-48698283.pdf?src-supplier=Conrad; ComponentLink7URL: https://datasheet.ciiva.com/48698/001086791-da-01-ml-tvs-diode-array-we-tvs-82400102-de-en-48698283.pdf?src-supplier=Conrad; Height: 1.16mm; Length: 2.9mm; Manufacturer Part Number: 82400102; Max Breakdown Voltage: 6V; Max Operating Temperature: 125°C; Min Breakdown Voltage: 6V; Min Operating Temperature: -40°C; Mount: Surface Mount; Number of Channels: 2; Number of Pins: 6; Operating Supply Voltage: 6V; Package Quantity: 1; PackageReference: SOT23_6L; Packaging: Tape and Reel; PartNumber: 82400102; Peak Pulse Current: 6A; Power Line Protection: Yes; Pricing 1: 1=0.84000, 10=0.80000, 50=0.74600, 100=0.69300, 500=0.61300 (USD); REACH SVHC: Unknown; Reverse Stand Off Voltage: 5V; Reverse Standoff Voltage: 5V; RoHS: Yes; RoHS Compliant: Yes; Series: WE-TVS; Stock 1: 490; Supplier 1: Digi-Key; Supplier Part Number 1: 732-4473-1-ND; Termination: SMD/SMT; Width: 2.8mm; Working Voltage: 5V; Library Name: stm32devsch.SchLib
U3 = STM32L151C8T6A_1: MCU 32-bit ARM Cortex M3 RISC 64KB Flash 2.5V/3.3V 48-Pin LQFP Tray; Manufacturer: STMicroelectronics; Core: ARM Cortex-M3; D/A Converter: 2; Data Bus Width: 32b; Data E2PROM nom (B): 4096; FLASH Size (Prog) (kB): 64; Frequency: 32MHz; I/Os (High Current): 37; Interface: I2C,SPI,USB,IrDA,UART,USART,LIN; Internal RAM Size (kB): 32; Max Power Dissipation: 364mW; Max Supply Voltage: 3.6V; Min Supply Voltage: 1.8V; Number of Timers/Counters: 8; Oscillator Type: Internal; Peripherals: Brown-out Detect/Reset,POR,PWM,WDT,DMA; RAM Size: 32KB; Supply Voltage(Vcc) min (V): 1.8; Watchdog Timer: Yes; Case/Package: LQFP; Category: Microcontrollers; Component Kind: Standard; ComponentLink1Description: Manufacturer URL; ComponentLink1URL: http://www.st.com/; ComponentLink2Description: Datasheet; ComponentLink2URL: http://www.st.com/st-web-ui/static/active/en/resource/technical/document/datasheet/DM00078689.pdf; ComponentLink3Description: http://www.farnell.com/datasheets/2308904.pdf?src-supplier=Farnell; ComponentLink3URL: http://www.farnell.com/datasheets/2308904.pdf?src-supplier=Farnell; ComponentLink4Description: http://www.mouser.com/ds/2/389/DM00078689-524617.pdf?src-supplier=Mouser; ComponentLink4URL: http://www.mouser.com/ds/2/389/DM00078689-524617.pdf?src-supplier=Mouser; ComponentLink5Description: http://www.st.com/content/ccc/resource/technical/document/datasheet/81/27/7d/29/b7/bd/49/f0/DM00078689.pdf/files/DM00078689.pdf/jcr:content/translations/en.DM00078689.pdf?src-supplier=Digi-Key; ComponentLink5URL: http://www.st.com/content/ccc/resource/technical/document/datasheet/81/27/7d/29/b7/bd/49/f0/DM00078689.pdf/files/DM00078689.pdf/jcr:content/translations/en.DM00078689.pdf?src-supplier=Digi-Key; ComponentLink6Description: http://www.st.com/web/en/resource/technical/document/datasheet/DM00098321.pdf?src-supplier=Avnet; ComponentLink6URL: http://www.st.com/web/en/resource/technical/document/datasheet/DM00098321.pdf?src-supplier=Avnet; ComponentLink7Description: http://www.farnell.com/datasheets/1897875.pdf?src-supplier=Element14; ComponentLink7URL: http://www.farnell.com/datasheets/1897875.pdf?src-supplier=Element14; ComponentLink8Description: http://www.st.com/web/en/resource/technical/document/datasheet/DM00098321.pdf?src-supplier=Avnet+Asia; ComponentLink8URL: http://www.st.com/web/en/resource/technical/document/datasheet/DM00098321.pdf?src-supplier=Avnet+Asia; Core Architecture: ARM; DatasheetVersion: Rev. 4; Lead Free: Lead Free; Manufacturer Part Number: STM32L151C8T6A; Max Frequency: 32MHz; Max Operating Temperature: 85°C; Memory Size: 64KB; Memory Type: FLASH; Min Operating Temperature: -40°C; Mount: Surface Mount; Mounting Technology: Surface Mount; Number of I/Os: 37; Number of Pins: 48; Number of Programmable I/O: 37; Operating Frequency(F) (Processor speed) (MHz): 32; Package Description: 48-Pin Low Profile Quad Flat Package, Body 7 x 7 mm, Pitch 0.5 mm; Package Reference: LQFP48; Packaging: Tray; Packing: Tray; PartNumber: STM32L151C8T6A; Pricing 1: 1=5.76000, 10=5.16900, 25=4.88720, 80=4.23538, 250=4.01820, 500=3.62000 (USD); REACH SVHC: No SVHC; RoHS: Yes; RoHS Compliant: Yes; Stock 1: 5; Supplier 1: Digi-Key; Supplier Part Number 1: 497-17514-ND; Library Name: stm32devsch.SchLib
U4 = IIS328DQ: High Performance Low power 3 axis accelerometer for industrial applications; Manufacturer: STMicroelectronics; Manufacturer Part Number: IIS328DQTR; Axis: X, Y, Z; Bandwidth: 500Hz; Max Supply Voltage: 3.6V; Min Supply Voltage: 2.16V; Output Type: SPI,I2C; Case/Package: QFN; Category: Accelerometers; Component Kind: Standard; ComponentLink1Description: http://www.farnell.com/datasheets/2028146.pdf?src-supplier=Farnell; ComponentLink1URL: http://www.farnell.com/datasheets/2028146.pdf?src-supplier=Farnell; ComponentLink2Description: http://www.st.com/st-web-ui/static/active/en/resource/technical/document/datasheet/DM00150114.pdf?src-supplier=Avnet; ComponentLink2URL: http://www.st.com/st-web-ui/static/active/en/resource/technical/document/datasheet/DM00150114.pdf?src-supplier=Avnet; ComponentLink3Description: http://www.st.com/content/ccc/resource/technical/document/datasheet/24/b9/50/c5/61/ae/4d/58/DM00150114.pdf/files/DM00150114.pdf/jcr:content/translations/en.DM00150114.pdf?src-supplier=Digi-Key; ComponentLink3URL: http://www.st.com/content/ccc/resource/technical/document/datasheet/24/b9/50/c5/61/ae/4d/58/DM00150114.pdf/files/DM00150114.pdf/jcr:content/translations/en.DM00150114.pdf?src-supplier=Digi-Key; ComponentLink4Description: http://www.farnell.com/datasheets/2028146.pdf?src-supplier=Newark; ComponentLink4URL: http://www.farnell.com/datasheets/2028146.pdf?src-supplier=Newark; ComponentLink5Description: http://www.farnell.com/datasheets/2028146.pdf?src-supplier=Element14; ComponentLink5URL: http://www.farnell.com/datasheets/2028146.pdf?src-supplier=Element14; Lead Free: Lead Free; Manufacturer_Part_Number: IIS328DQ; Max Operating Temperature: 105°C; Mfr_Name: STMicroelectronics; Min Operating Temperature: -40°C; Mount: Surface Mount; Number of Pins: 24; Packaging: Cut Tape; Pricing 1: 1=5.32000, 10=4.77800, 25=4.51680, 100=3.91440, 500=3.34564 (USD); REACH SVHC: No SVHC; RefDes: RefDes; RoHS: Yes; Stock 1: 30; Supplier 1: Digi-Key; Supplier Part Number 1: 497-15701-1-ND; Type: DEV; Copyright: Copyright (C) 2021 Ultra Librarian. All rights reserved.; Library Name: stm32devsch.SchLib
Y1 = Crys_ECS_Inc._ECS-160-20-3X-TR_eec: Crystals 16MHz 20pF 50ppm -10C +70C; Manufacturer: ECS Inc.; ESR (Equivalent Series Resistance): 50R; Frequency Tolerance: 30ppm; Category: Crystals; Component Kind: Standard; ComponentLink1Description: Upverter; ComponentLink1URL: https://upverter.com/upn/revision/104864cf044a7037/; ComponentLink2Description: Datasheet; ComponentLink2URL: https://upverter.com/datasheet/2e9e06c1bbd9708d42261281cd9889adb95c2d1a.pdf; ComponentLink3Description: https://datasheet.ciiva.com/852/csm-3x-852328.pdf?src-supplier=Digi-Key; ComponentLink3URL: https://datasheet.ciiva.com/852/csm-3x-852328.pdf?src-supplier=Digi-Key; ComponentLink4Description: https://datasheet.ciiva.com/852/csm-3x-852329.pdf?src-supplier=Digi-Key; ComponentLink4URL: https://datasheet.ciiva.com/852/csm-3x-852329.pdf?src-supplier=Digi-Key; ComponentLink5Description: https://datasheet.ciiva.com/852/csm-3x-852330.pdf?src-supplier=Digi-Key; ComponentLink5URL: https://datasheet.ciiva.com/852/csm-3x-852330.pdf?src-supplier=Digi-Key; Digikey Description: CRYSTAL 16.0000MHZ 20PF SMD; Digikey Part Number: XC1776CT-ND; Frequency: 16MHz; Frequency Stability: 50ppm; Height: 0.091inch; helpURL: https://upverter.com/upn/revision/104864cf044a7037/; Lead Free: yes; Length: 0.276inch; Load Capacitance: 20pF; Manufacturer Part Number: ECS-160-20-3X-TR; Max Operating Temperature: 70°C; Min Operating Temperature: -10°C; Mount: Surface Mount; Mouser Part Number: 520-160-20-3XT; Package: XTAL_SMD_7MM0_4MM1; Package Quantity: 1; Packaging: Tape and Reel; Part Number: ECS-160-20-3X-TR; Price: 0.261262821276; Pricing 1: 1=0.34000, 10=0.28800, 50=0.25880, 100=0.23000, 500=0.21850, 1000=0.18400 (USD); RoHS: TRUE; Series: CSM-3X; Stock 1: 87073; Supplier 1: Digi-Key; Supplier 2: Mouser; Supplier Part Number 1: XC1776CT-ND; Supplier Part Number 2: 520-160-20-3XT; Temperature: -10°C to +70°C; Temperature Range High: +70°C; Temperature Range Low: -10°C; Termination: SMD/SMT; Tolerance: 30ppm; Width: 0.161inch; Library Name: stm32devsch.SchLib
